# Altium schematic sheet CM001_V4_3.SchDoc(CM001_V4_3)
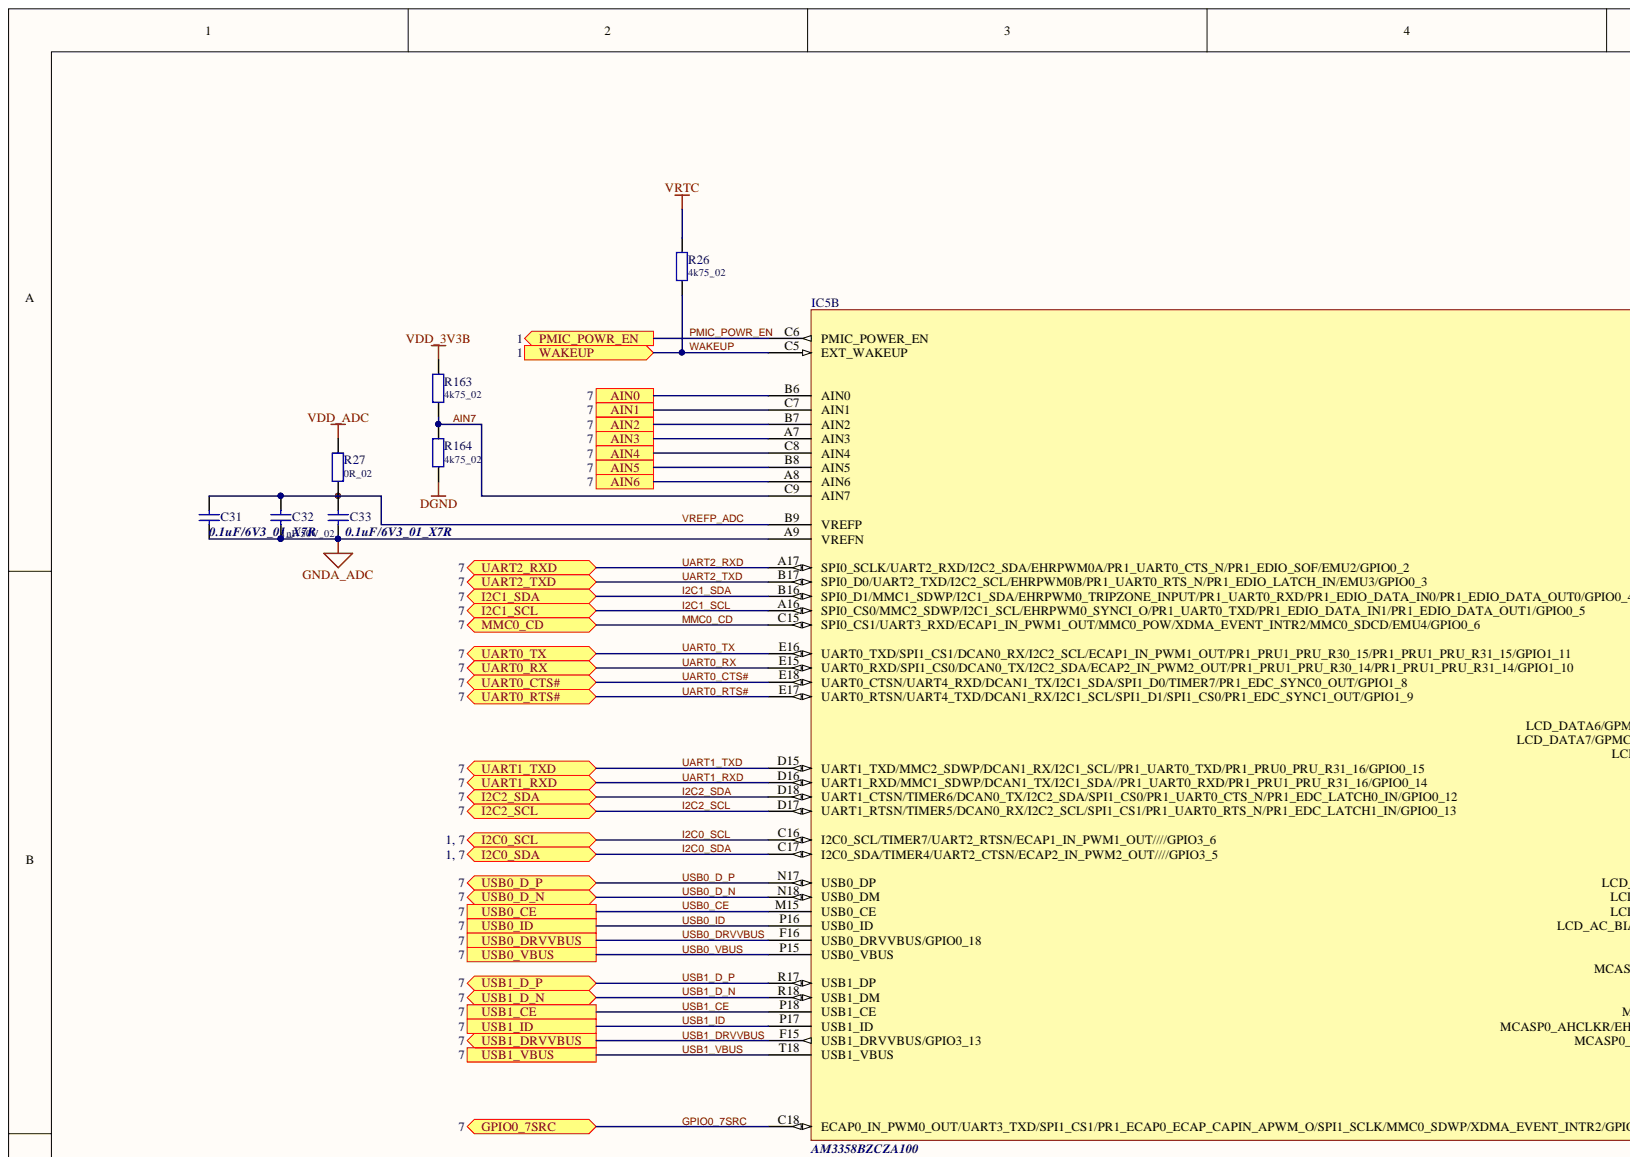
[diagram p0: CM001_V4_3.SchDoc, top left]
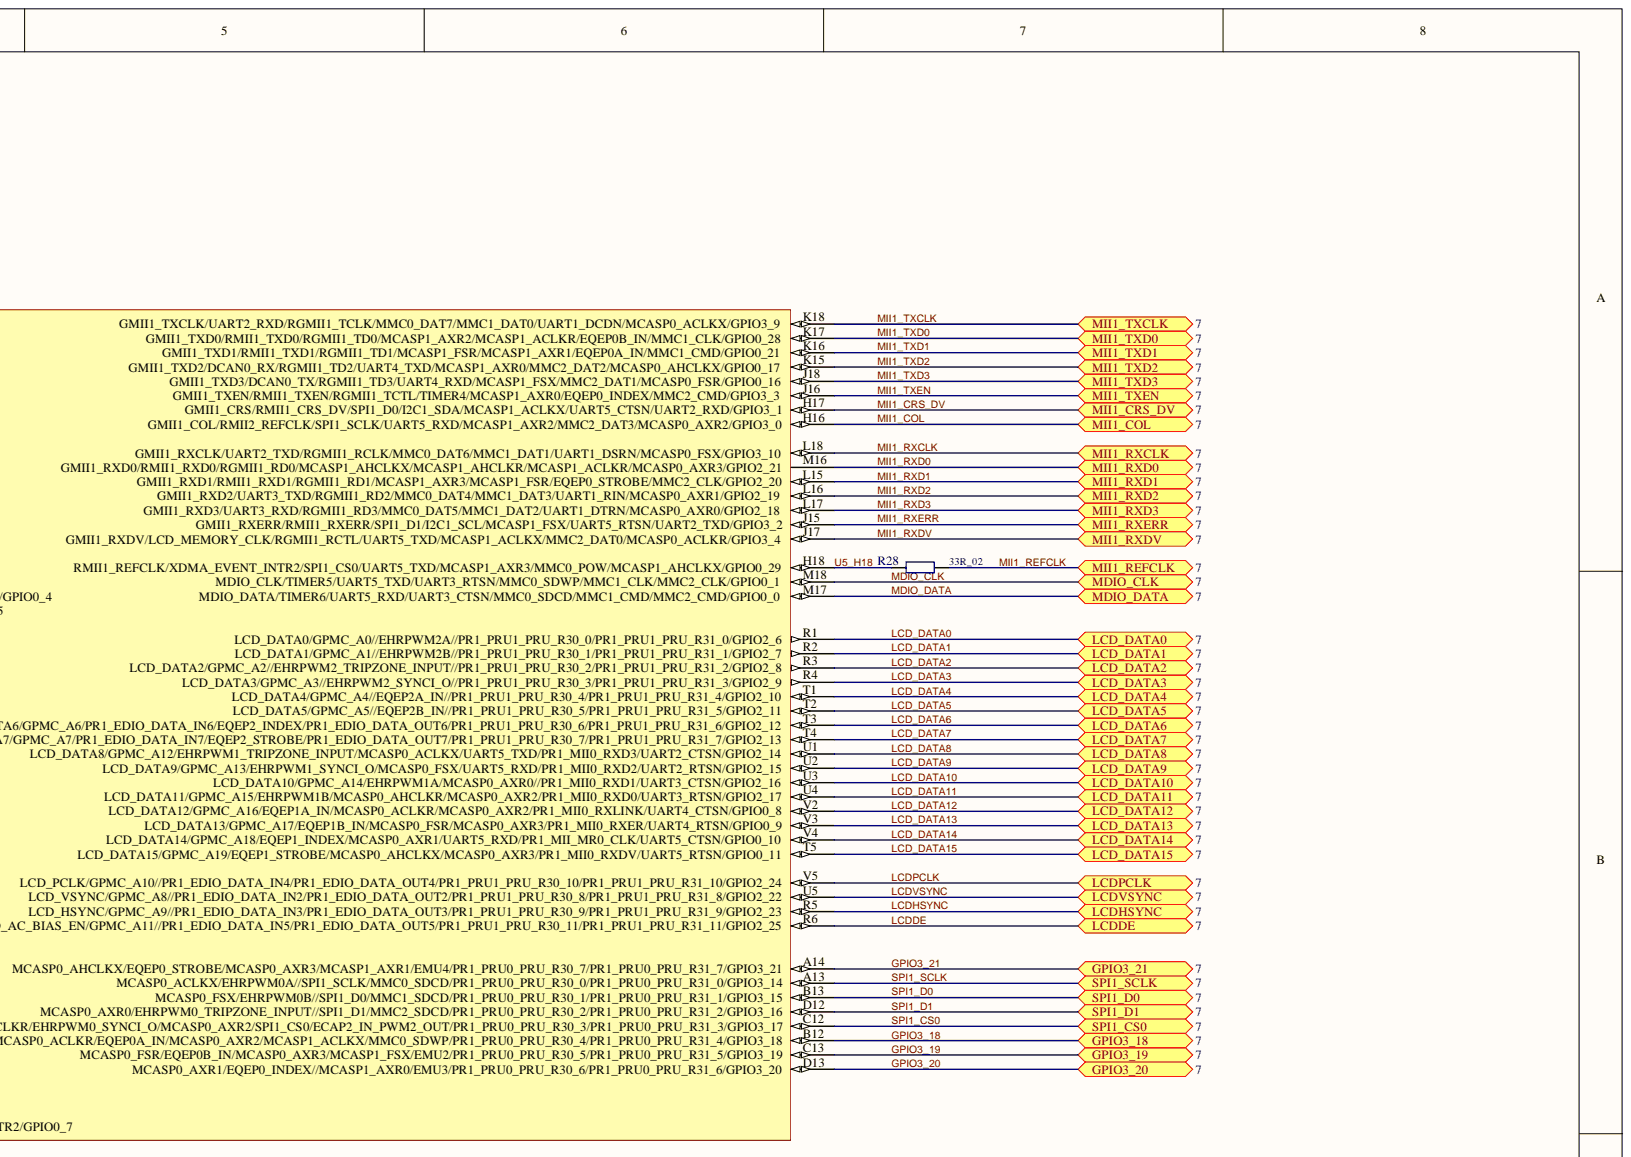
[diagram p1: CM001_V4_3.SchDoc, top right]
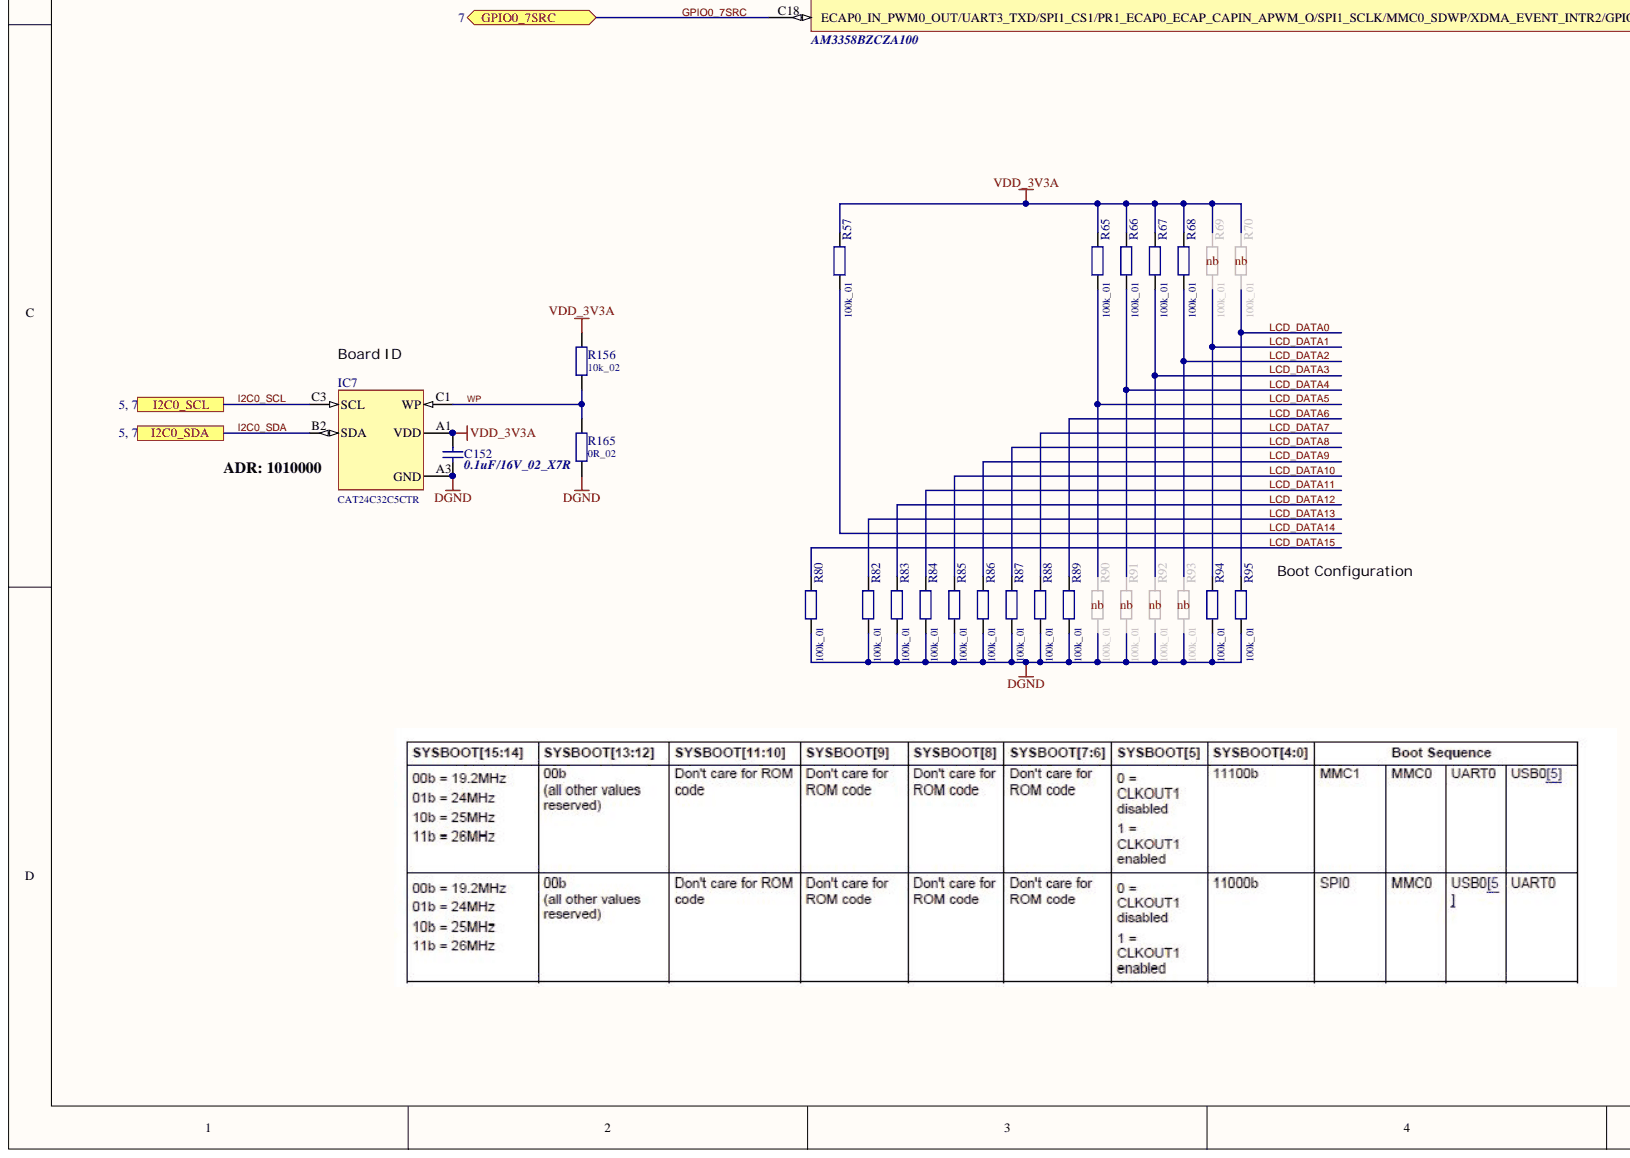
[diagram p2: CM001_V4_3.SchDoc, bottom left]
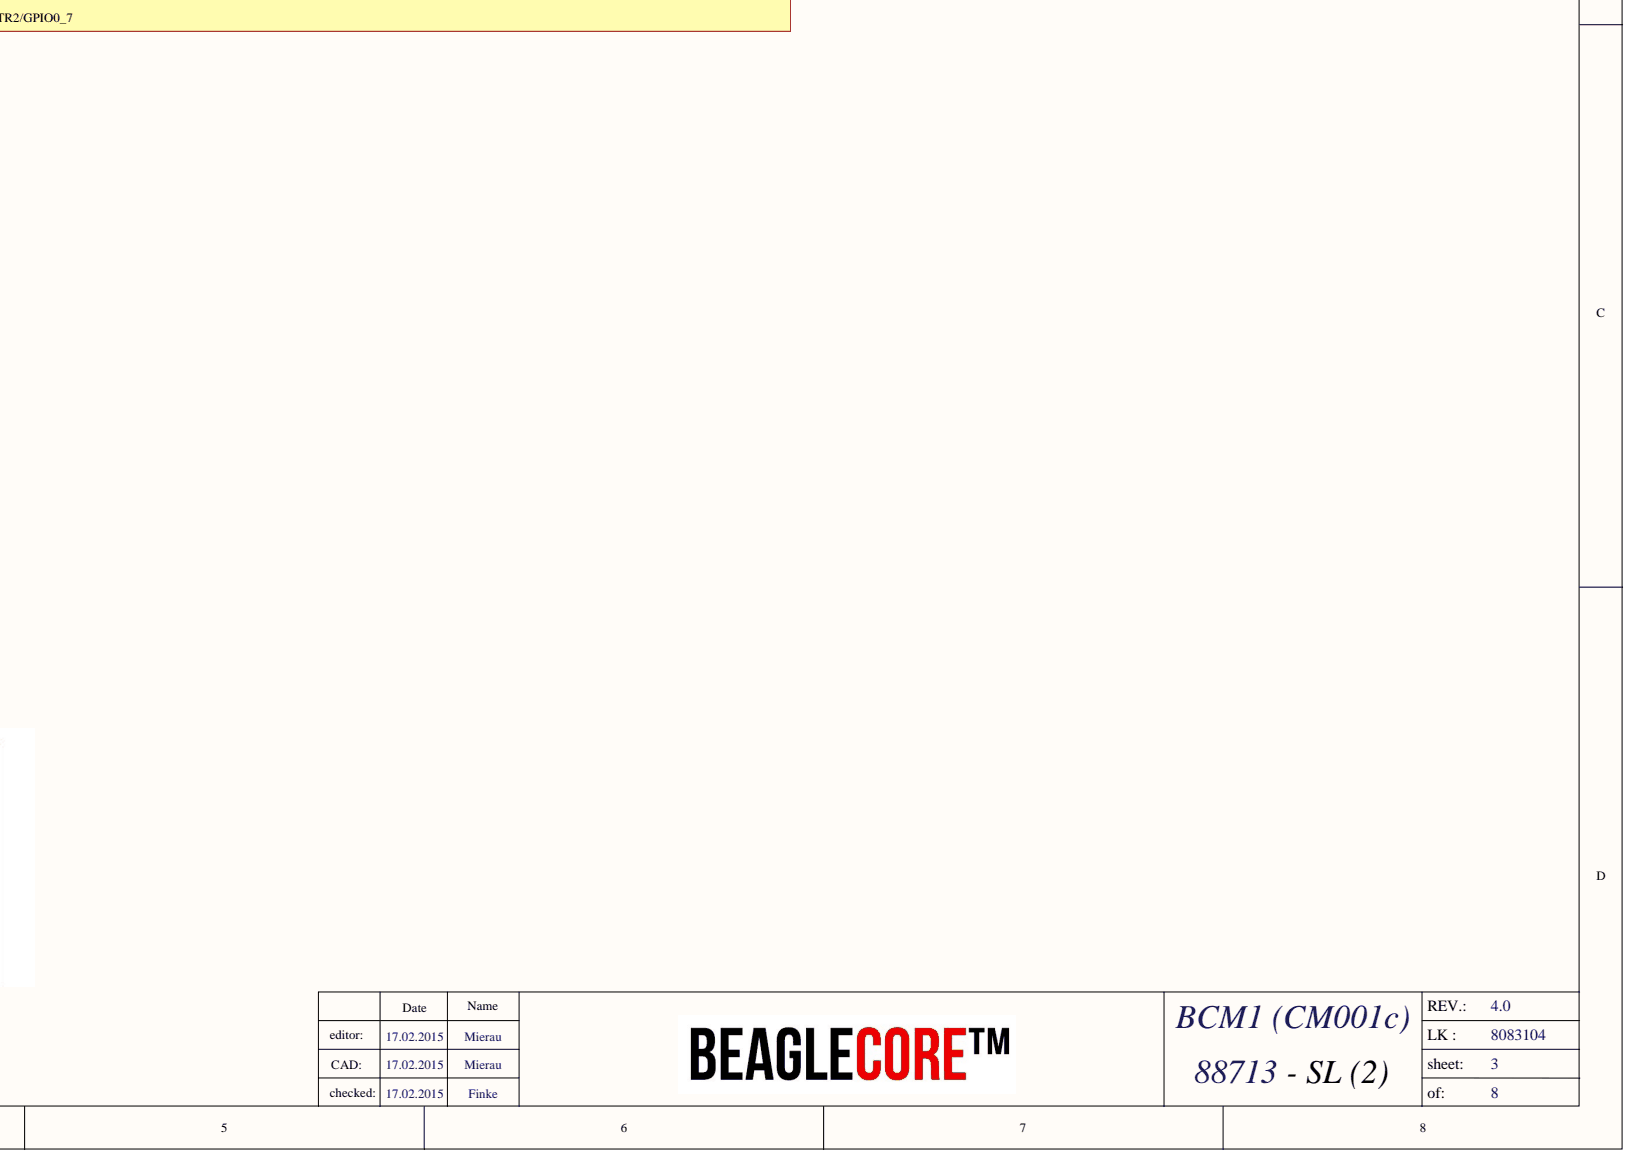
[diagram p3: CM001_V4_3.SchDoc, bottom right]

## Parts
C31  0.1uF/6V3_01_X7R  [CC0603-0201]
C31
C32  1nF/50V_02  [CC1005-0402]
C33  0.1uF/6V3_01_X7R  [CC0603-0201]
C33
C152  0.1uF/16V_02_X7R  [CC1005-0402]
C152  0.1uF/16V_02_X7R  [CC1005-0402]
IC5  AM3358BZCZ100  [BGA324_RM0.8_15x15]  (value not drawn)  (part B)
IC7  CAT24C32C5CTR  [WLCSP5]
R26  4k75_02  [CR1005-0402]
R27  0R_02  [CR1005-0402]
R28  33R_02  [CR1005-0402]
R57  100k_01  [CR0603-0201]
R65  100k_01  [CR0603-0201]
R66  100k_01  [CR0603-0201]
R67  100k_01  [CR0603-0201]
R68  100k_01  [CR0603-0201]
R69  100k_01  [CR0603-0201]
R70  100k_01  [CR0603-0201]
R80  100k_01  [CR0603-0201]
R82  100k_01  [CR0603-0201]
R83  100k_01  [CR0603-0201]
R84  100k_01  [CR0603-0201]
R85  100k_01  [CR0603-0201]
R86  100k_01  [CR0603-0201]
R87  100k_01  [CR0603-0201]
R88  100k_01  [CR0603-0201]
R89  100k_01  [CR0603-0201]
R90  100k_01  [CR0603-0201]
R91  100k_01  [CR0603-0201]
R92  100k_01  [CR0603-0201]
R93  100k_01  [CR0603-0201]
R94  100k_01  [CR0603-0201]
R95  100k_01  [CR0603-0201]
R156  10k_02  [CR1005-0402]
R163  4k75_02  [CR1005-0402]
R164  4k75_02  [CR1005-0402]
R165  0R_02  [CR1005-0402]

## Ports
AIN0, AIN1, AIN2, AIN3, AIN4, AIN5, AIN6, GPIO0_7SRC, GPIO3_18, GPIO3_19, GPIO3_20, GPIO3_21, I2C0_SCL, I2C0_SDA, I2C1_SCL, I2C1_SDA, I2C2_SCL, I2C2_SDA, LCD_DATA0, LCD_DATA1, LCD_DATA2, LCD_DATA3, LCD_DATA4, LCD_DATA5, LCD_DATA6, LCD_DATA7, LCD_DATA8, LCD_DATA9, LCD_DATA10, LCD_DATA11, LCD_DATA12, LCD_DATA13, LCD_DATA14, LCD_DATA15, LCDDE, LCDHSYNC, LCDPCLK, LCDVSYNC, MDIO_CLK, MDIO_DATA, MII1_COL, MII1_CRS_DV, MII1_REFCLK, MII1_RXCLK, MII1_RXD0, MII1_RXD1, MII1_RXD2, MII1_RXD3, MII1_RXDV, MII1_RXERR, MII1_TXCLK, MII1_TXD0, MII1_TXD1, MII1_TXD2, MII1_TXD3, MII1_TXEN, MMC0_CD, PMIC_POWR_EN, SPI1_CS0, SPI1_D0, SPI1_D1, SPI1_SCLK, UART0_CTS#, UART0_RTS#, UART0_RX, UART0_TX, UART1_RXD, UART1_TXD, UART2_RXD, UART2_TXD, USB0_CE, USB0_D_N, USB0_D_P, USB0_DRVVBUS, USB0_ID, USB0_VBUS, USB1_CE, USB1_D_N, USB1_D_P, USB1_DRVVBUS, USB1_ID, USB1_VBUS, WAKEUP

## Nets
DGND: C152.2 IC7.A3(GND) R80.1 R82.1 R83.1 R84.1 R85.1 R86.1 R87.1 R88.1 R89.1 R90.1 R91.1 R92.1 R93.1 R94.1 R95.1 R164.1 R165.1
GNDA_ADC: C31.1 C32.1 C33.1 IC5.A9(VREFN)
VDD_3V3A: C152.1 IC7.A1(VDD) R57.2 R65.2 R66.2 R67.2 R68.2 R69.2 R70.2 R156.2
VDD_3V3B: R163.2
VDD_ADC: R27.2
VRTC: R26.2
AIN0: IC5.B6(AIN0) ; port AIN0
AIN1: IC5.C7(AIN1) ; port AIN1
AIN2: IC5.B7(AIN2) ; port AIN2
AIN3: IC5.A7(AIN3) ; port AIN3
AIN4: IC5.C8(AIN4) ; port AIN4
AIN5: IC5.B8(AIN5) ; port AIN5
AIN6: IC5.A8(AIN6) ; port AIN6
AIN7: IC5.C9(AIN7) R163.1 R164.2
GPIO0_7SRC: IC5.C18(ECAP0_IN_PWM0_OUT/UART3_TXD/SPI1_CS1/PR1_ECAP0_ECAP_CAPIN_APWM_O/SPI1_SCLK/MMC0_SDWP/XDMA_EVENT_INTR2/GPIO0_7) ; port GPIO0_7SRC
GPIO3_18: IC5.B12(MCASP0_ACLKR/EQEP0A_IN/MCASP0_AXR2/MCASP1_ACLKX/MMC0_SDWP/PR1_PRU0_PRU_R30_4/PR1_PRU0_PRU_R31_4/GPIO3_18) ; port GPIO3_18
GPIO3_19: IC5.C13(MCASP0_FSR/EQEP0B_IN/MCASP0_AXR3/MCASP1_FSX/EMU2/PR1_PRU0_PRU_R30_5/PR1_PRU0_PRU_R31_5/GPIO3_19) ; port GPIO3_19
GPIO3_20: IC5.D13(MCASP0_AXR1/EQEP0_INDEX//MCASP1_AXR0/EMU3/PR1_PRU0_PRU_R30_6/PR1_PRU0_PRU_R31_6/GPIO3_20) ; port GPIO3_20
GPIO3_21: IC5.A14(MCASP0_AHCLKX/EQEP0_STROBE/MCASP0_AXR3/MCASP1_AXR1/EMU4/PR1_PRU0_PRU_R30_7/PR1_PRU0_PRU_R31_7/GPIO3_21) ; port GPIO3_21
I2C0_SCL: IC5.C16(I2C0_SCL/TIMER7/UART2_RTSN/ECAP1_IN_PWM1_OUT////GPIO3_6) IC7.C3(SCL) ; port I2C0_SCL
I2C0_SDA: IC5.C17(I2C0_SDA/TIMER4/UART2_CTSN/ECAP2_IN_PWM2_OUT////GPIO3_5) IC7.B2(SDA) ; port I2C0_SDA
I2C1_SCL: IC5.A16(SPI0_CS0/MMC2_SDWP/I2C1_SCL/EHRPWM0_SYNCI_O/PR1_UART0_TXD/PR1_EDIO_DATA_IN1/PR1_EDIO_DATA_OUT1/GPIO0_5) ; port I2C1_SCL
I2C1_SDA: IC5.B16(SPI0_D1/MMC1_SDWP/I2C1_SDA/EHRPWM0_TRIPZONE_INPUT/PR1_UART0_RXD/PR1_EDIO_DATA_IN0/PR1_EDIO_DATA_OUT0/GPIO0_4) ; port I2C1_SDA
I2C2_SCL: IC5.D17(UART1_RTSN/TIMER5/DCAN0_RX/I2C2_SCL/SPI1_CS1/PR1_UART0_RTS_N/PR1_EDC_LATCH1_IN/GPIO0_13) ; port I2C2_SCL
I2C2_SDA: IC5.D18(UART1_CTSN/TIMER6/DCAN0_TX/I2C2_SDA/SPI1_CS0/PR1_UART0_CTS_N/PR1_EDC_LATCH0_IN/GPIO0_12) ; port I2C2_SDA
LCD_DATA0: IC5.R1(LCD_DATA0/GPMC_A0//EHRPWM2A//PR1_PRU1_PRU_R30_0/PR1_PRU1_PRU_R31_0/GPIO2_6) R70.1 R95.2 ; port LCD_DATA0
LCD_DATA1: IC5.R2(LCD_DATA1/GPMC_A1//EHRPWM2B//PR1_PRU1_PRU_R30_1/PR1_PRU1_PRU_R31_1/GPIO2_7) R69.1 R94.2 ; port LCD_DATA1
LCD_DATA2: IC5.R3(LCD_DATA2/GPMC_A2//EHRPWM2_TRIPZONE_INPUT//PR1_PRU1_PRU_R30_2/PR1_PRU1_PRU_R31_2/GPIO2_8) R68.1 R93.2 ; port LCD_DATA2
LCD_DATA3: IC5.R4(LCD_DATA3/GPMC_A3//EHRPWM2_SYNCI_O//PR1_PRU1_PRU_R30_3/PR1_PRU1_PRU_R31_3/GPIO2_9) R67.1 R92.2 ; port LCD_DATA3
LCD_DATA4: IC5.T1(LCD_DATA4/GPMC_A4//EQEP2A_IN//PR1_PRU1_PRU_R30_4/PR1_PRU1_PRU_R31_4/GPIO2_10) R66.1 R91.2 ; port LCD_DATA4
LCD_DATA5: IC5.T2(LCD_DATA5/GPMC_A5//EQEP2B_IN//PR1_PRU1_PRU_R30_5/PR1_PRU1_PRU_R31_5/GPIO2_11) R65.1 R90.2 ; port LCD_DATA5
LCD_DATA6: IC5.T3(LCD_DATA6/GPMC_A6/PR1_EDIO_DATA_IN6/EQEP2_INDEX/PR1_EDIO_DATA_OUT6/PR1_PRU1_PRU_R30_6/PR1_PRU1_PRU_R31_6/GPIO2_12) R89.2 ; port LCD_DATA6
LCD_DATA7: IC5.T4(LCD_DATA7/GPMC_A7/PR1_EDIO_DATA_IN7/EQEP2_STROBE/PR1_EDIO_DATA_OUT7/PR1_PRU1_PRU_R30_7/PR1_PRU1_PRU_R31_7/GPIO2_13) R88.2 ; port LCD_DATA7
LCD_DATA8: IC5.U1(LCD_DATA8/GPMC_A12/EHRPWM1_TRIPZONE_INPUT/MCASP0_ACLKX/UART5_TXD/PR1_MII0_RXD3/UART2_CTSN/GPIO2_14) R87.2 ; port LCD_DATA8
LCD_DATA9: IC5.U2(LCD_DATA9/GPMC_A13/EHRPWM1_SYNCI_O/MCASP0_FSX/UART5_RXD/PR1_MII0_RXD2/UART2_RTSN/GPIO2_15) R86.2 ; port LCD_DATA9
LCD_DATA10: IC5.U3(LCD_DATA10/GPMC_A14/EHRPWM1A/MCASP0_AXR0//PR1_MII0_RXD1/UART3_CTSN/GPIO2_16) R85.2 ; port LCD_DATA10
LCD_DATA11: IC5.U4(LCD_DATA11/GPMC_A15/EHRPWM1B/MCASP0_AHCLKR/MCASP0_AXR2/PR1_MII0_RXD0/UART3_RTSN/GPIO2_17) R84.2 ; port LCD_DATA11
LCD_DATA12: IC5.V2(LCD_DATA12/GPMC_A16/EQEP1A_IN/MCASP0_ACLKR/MCASP0_AXR2/PR1_MII0_RXLINK/UART4_CTSN/GPIO0_8) R83.2 ; port LCD_DATA12
LCD_DATA13: IC5.V3(LCD_DATA13/GPMC_A17/EQEP1B_IN/MCASP0_FSR/MCASP0_AXR3/PR1_MII0_RXER/UART4_RTSN/GPIO0_9) R82.2 ; port LCD_DATA13
LCD_DATA14: IC5.V4(LCD_DATA14/GPMC_A18/EQEP1_INDEX/MCASP0_AXR1/UART5_RXD/PR1_MII_MR0_CLK/UART5_CTSN/GPIO0_10) R57.1 ; port LCD_DATA14
LCD_DATA15: IC5.T5(LCD_DATA15/GPMC_A19/EQEP1_STROBE/MCASP0_AHCLKX/MCASP0_AXR3/PR1_MII0_RXDV/UART5_RTSN/GPIO0_11) R80.2 ; port LCD_DATA15
LCDDE: IC5.R6(LCD_AC_BIAS_EN/GPMC_A11//PR1_EDIO_DATA_IN5/PR1_EDIO_DATA_OUT5/PR1_PRU1_PRU_R30_11/PR1_PRU1_PRU_R31_11/GPIO2_25) ; port LCDDE
LCDHSYNC: IC5.R5(LCD_HSYNC/GPMC_A9//PR1_EDIO_DATA_IN3/PR1_EDIO_DATA_OUT3/PR1_PRU1_PRU_R30_9/PR1_PRU1_PRU_R31_9/GPIO2_23) ; port LCDHSYNC
LCDPCLK: IC5.V5(LCD_PCLK/GPMC_A10//PR1_EDIO_DATA_IN4/PR1_EDIO_DATA_OUT4/PR1_PRU1_PRU_R30_10/PR1_PRU1_PRU_R31_10/GPIO2_24) ; port LCDPCLK
LCDVSYNC: IC5.U5(LCD_VSYNC/GPMC_A8//PR1_EDIO_DATA_IN2/PR1_EDIO_DATA_OUT2/PR1_PRU1_PRU_R30_8/PR1_PRU1_PRU_R31_8/GPIO2_22) ; port LCDVSYNC
MDIO_CLK: IC5.M18(MDIO_CLK/TIMER5/UART5_TXD/UART3_RTSN/MMC0_SDWP/MMC1_CLK/MMC2_CLK/GPIO0_1) ; port MDIO_CLK
MDIO_DATA: IC5.M17(MDIO_DATA/TIMER6/UART5_RXD/UART3_CTSN/MMC0_SDCD/MMC1_CMD/MMC2_CMD/GPIO0_0) ; port MDIO_DATA
MII1_COL: IC5.H16(GMII1_COL/RMII2_REFCLK/SPI1_SCLK/UART5_RXD/MCASP1_AXR2/MMC2_DAT3/MCASP0_AXR2/GPIO3_0) ; port MII1_COL
MII1_CRS_DV: IC5.H17(GMII1_CRS/RMII1_CRS_DV/SPI1_D0/I2C1_SDA/MCASP1_ACLKX/UART5_CTSN/UART2_RXD/GPIO3_1) ; port MII1_CRS_DV
MII1_REFCLK: R28.2 ; port MII1_REFCLK
MII1_RXCLK: IC5.L18(GMII1_RXCLK/UART2_TXD/RGMII1_RCLK/MMC0_DAT6/MMC1_DAT1/UART1_DSRN/MCASP0_FSX/GPIO3_10) ; port MII1_RXCLK
MII1_RXD0: IC5.M16(GMII1_RXD0/RMII1_RXD0/RGMII1_RD0/MCASP1_AHCLKX/MCASP1_AHCLKR/MCASP1_ACLKR/MCASP0_AXR3/GPIO2_21) ; port MII1_RXD0
MII1_RXD1: IC5.L15(GMII1_RXD1/RMII1_RXD1/RGMII1_RD1/MCASP1_AXR3/MCASP1_FSR/EQEP0_STROBE/MMC2_CLK/GPIO2_20) ; port MII1_RXD1
MII1_RXD2: IC5.L16(GMII1_RXD2/UART3_TXD/RGMII1_RD2/MMC0_DAT4/MMC1_DAT3/UART1_RIN/MCASP0_AXR1/GPIO2_19) ; port MII1_RXD2
MII1_RXD3: IC5.L17(GMII1_RXD3/UART3_RXD/RGMII1_RD3/MMC0_DAT5/MMC1_DAT2/UART1_DTRN/MCASP0_AXR0/GPIO2_18) ; port MII1_RXD3
MII1_RXDV: IC5.J17(GMII1_RXDV/LCD_MEMORY_CLK/RGMII1_RCTL/UART5_TXD/MCASP1_ACLKX/MMC2_DAT0/MCASP0_ACLKR/GPIO3_4) ; port MII1_RXDV
MII1_RXERR: IC5.J15(GMII1_RXERR/RMII1_RXERR/SPI1_D1/I2C1_SCL/MCASP1_FSX/UART5_RTSN/UART2_TXD/GPIO3_2) ; port MII1_RXERR
MII1_TXCLK: IC5.K18(GMII1_TXCLK/UART2_RXD/RGMII1_TCLK/MMC0_DAT7/MMC1_DAT0/UART1_DCDN/MCASP0_ACLKX/GPIO3_9) ; port MII1_TXCLK
MII1_TXD0: IC5.K17(GMII1_TXD0/RMII1_TXD0/RGMII1_TD0/MCASP1_AXR2/MCASP1_ACLKR/EQEP0B_IN/MMC1_CLK/GPIO0_28) ; port MII1_TXD0
MII1_TXD1: IC5.K16(GMII1_TXD1/RMII1_TXD1/RGMII1_TD1/MCASP1_FSR/MCASP1_AXR1/EQEP0A_IN/MMC1_CMD/GPIO0_21) ; port MII1_TXD1
MII1_TXD2: IC5.K15(GMII1_TXD2/DCAN0_RX/RGMII1_TD2/UART4_TXD/MCASP1_AXR0/MMC2_DAT2/MCASP0_AHCLKX/GPIO0_17) ; port MII1_TXD2
MII1_TXD3: IC5.J18(GMII1_TXD3/DCAN0_TX/RGMII1_TD3/UART4_RXD/MCASP1_FSX/MMC2_DAT1/MCASP0_FSR/GPIO0_16) ; port MII1_TXD3
MII1_TXEN: IC5.J16(GMII1_TXEN/RMII1_TXEN/RGMII1_TCTL/TIMER4/MCASP1_AXR0/EQEP0_INDEX/MMC2_CMD/GPIO3_3) ; port MII1_TXEN
MMC0_CD: IC5.C15(SPI0_CS1/UART3_RXD/ECAP1_IN_PWM1_OUT/MMC0_POW/XDMA_EVENT_INTR2/MMC0_SDCD/EMU4/GPIO0_6) ; port MMC0_CD
PMIC_POWR_EN: IC5.C6(PMIC_POWER_EN) ; port PMIC_POWR_EN
SPI1_CS0: IC5.C12(MCASP0_AHCLKR/EHRPWM0_SYNCI_O/MCASP0_AXR2/SPI1_CS0/ECAP2_IN_PWM2_OUT/PR1_PRU0_PRU_R30_3/PR1_PRU0_PRU_R31_3/GPIO3_17) ; port SPI1_CS0
SPI1_D0: IC5.B13(MCASP0_FSX/EHRPWM0B//SPI1_D0/MMC1_SDCD/PR1_PRU0_PRU_R30_1/PR1_PRU0_PRU_R31_1/GPIO3_15) ; port SPI1_D0
SPI1_D1: IC5.D12(MCASP0_AXR0/EHRPWM0_TRIPZONE_INPUT//SPI1_D1/MMC2_SDCD/PR1_PRU0_PRU_R30_2/PR1_PRU0_PRU_R31_2/GPIO3_16) ; port SPI1_D1
SPI1_SCLK: IC5.A13(MCASP0_ACLKX/EHRPWM0A//SPI1_SCLK/MMC0_SDCD/PR1_PRU0_PRU_R30_0/PR1_PRU0_PRU_R31_0/GPIO3_14) ; port SPI1_SCLK
U5_H18: IC5.H18(RMII1_REFCLK/XDMA_EVENT_INTR2/SPI1_CS0/UART5_TXD/MCASP1_AXR3/MMC0_POW/MCASP1_AHCLKX/GPIO0_29) R28.1
UART0_CTS#: IC5.E18(UART0_CTSN/UART4_RXD/DCAN1_TX/I2C1_SDA/SPI1_D0/TIMER7/PR1_EDC_SYNC0_OUT/GPIO1_8) ; port UART0_CTS#
UART0_RTS#: IC5.E17(UART0_RTSN/UART4_TXD/DCAN1_RX/I2C1_SCL/SPI1_D1/SPI1_CS0/PR1_EDC_SYNC1_OUT/GPIO1_9) ; port UART0_RTS#
UART0_RX: IC5.E15(UART0_RXD/SPI1_CS0/DCAN0_TX/I2C2_SDA/ECAP2_IN_PWM2_OUT/PR1_PRU1_PRU_R30_14/PR1_PRU1_PRU_R31_14/GPIO1_10) ; port UART0_RX
UART0_TX: IC5.E16(UART0_TXD/SPI1_CS1/DCAN0_RX/I2C2_SCL/ECAP1_IN_PWM1_OUT/PR1_PRU1_PRU_R30_15/PR1_PRU1_PRU_R31_15/GPIO1_11) ; port UART0_TX
UART1_RXD: IC5.D16(UART1_RXD/MMC1_SDWP/DCAN1_TX/I2C1_SDA//PR1_UART0_RXD/PR1_PRU1_PRU_R31_16/GPIO0_14) ; port UART1_RXD
UART1_TXD: IC5.D15(UART1_TXD/MMC2_SDWP/DCAN1_RX/I2C1_SCL//PR1_UART0_TXD/PR1_PRU0_PRU_R31_16/GPIO0_15) ; port UART1_TXD
UART2_RXD: IC5.A17(SPI0_SCLK/UART2_RXD/I2C2_SDA/EHRPWM0A/PR1_UART0_CTS_N/PR1_EDIO_SOF/EMU2/GPIO0_2) ; port UART2_RXD
UART2_TXD: IC5.B17(SPI0_D0/UART2_TXD/I2C2_SCL/EHRPWM0B/PR1_UART0_RTS_N/PR1_EDIO_LATCH_IN/EMU3/GPIO0_3) ; port UART2_TXD
USB0_CE: IC5.M15(USB0_CE) ; port USB0_CE
USB0_D_N: IC5.N18(USB0_DM) ; port USB0_D_N
USB0_D_P: IC5.N17(USB0_DP) ; port USB0_D_P
USB0_DRVVBUS: IC5.F16(USB0_DRVVBUS/GPIO0_18) ; port USB0_DRVVBUS
USB0_ID: IC5.P16(USB0_ID) ; port USB0_ID
USB0_VBUS: IC5.P15(USB0_VBUS) ; port USB0_VBUS
USB1_CE: IC5.P18(USB1_CE) ; port USB1_CE
USB1_D_N: IC5.R18(USB1_DM) ; port USB1_D_N
USB1_D_P: IC5.R17(USB1_DP) ; port USB1_D_P
USB1_DRVVBUS: IC5.F15(USB1_DRVVBUS/GPIO3_13) ; port USB1_DRVVBUS
USB1_ID: IC5.P17(USB1_ID) ; port USB1_ID
USB1_VBUS: IC5.T18(USB1_VBUS) ; port USB1_VBUS
VREFP_ADC: C31.2 C32.2 C33.2 IC5.B9(VREFP) R27.1
WAKEUP: IC5.C5(EXT_WAKEUP) R26.1 ; port WAKEUP
WP: IC7.C1(WP) R156.1 R165.2
N00246:
N00247:
N00248:
N00249:

## Library data of the parts
C31 C33 = C0.1uF/6V3_01_X7R: Capacitor 0201 6,3V X7R; S/T: SMD; Hersteller: any; erstellt von: Finke; Art-Nr.: 08.0002.0___; Produktbeispiel: Gudeco: muRata: GRM033R70J103JA01D; Value: 0.1uF/6V3_01_X7R; Supplier Part Number 2: 8USl_ZajxFVxkJsfRx-2TMMxBpVDD3GHQuOpPGq7oYPTEIlO4Ffw3x4_A6bjf4Mm; Supplier Part Number 1: 490-3167-6-ND; Supplier 2: Arrow; Supplier 1: Digi-Key; Component Kind: Standard; Bestellbezeichnung: *; Library Name: C_ies_Integrated_Library.IntLib
C32 = C1nF/50V_02: Capacitor 0402 X7R 50V; S/T: SMD; Erstellt von: Krüger; Art-Nr.: 08.0002.0009; Value: 1nF/50V_02; Component Kind: Standard; Library Name: C_ies_Integrated_Library.IntLib
C152 = C0.1uF/16V_02_X7R: Capacitor 0402 16V X7R; S/T: SMD; Hersteller1: muRata; Bestellbezeichnung1: GCM188R70J225KE22D; Art-Nr.: 08.0002.0___; Value: 0.1uF/16V_02_X7R; Lieferant: *; Hersteller: *; Component Kind: Standard; Bestellbezeichnung: *; Library Name: C_ies_Integrated_Library.IntLib
C152 = C0.1uF/16V_02_X7R: Capacitor 0402 16V X7R; S/T: SMD; erstellt von: FAD; Art-Nr.: 08.0002.0___; Bestellbezeichnung1: GCM188R70J225KE22D; Hersteller1: muRata; Value: 0.1uF/16V_02_X7R; Lieferant: *; Hersteller: *; Component Kind: Standard; Bestellbezeichnung: *; Library Name: C_ies_Integrated_Library.IntLib
IC5 = ICAM3358BZCZ100: Cortex A8 Processor 15x15; Hersteller: Texas Instruments; Art-Nr.: 04.0701.; Value: AM3358BZCZ100; ComponentLink1URL: http://www.ti.com/lit/ds/symlink/am3354.pdf; ComponentLink1Description: Link TI; Component Kind: Standard; Bestellbezeichnung: AM3358BZCZ100; Library Name: IC_ies_Integrated_Library.IntLib
IC7 = ICCAT24C32C5CTR: Serial EEPROM; 2-wire, 8192 x 8 bits; S/T: SMD; Hersteller: ON SEMI; Art-Nr.: 04.0701.; Value: CAT24C32C5CTR; ComponentLink1Description: Datenblatt; Component Kind: Standard; Bestellbezeichnung: CAT24C32C5CTR; Library Name: IC_ies_Integrated_Library.IntLib; ComponentLink1URL: U:\IC_Integrierte Bauteile\ON Semi\CAT24C32-D.PDF
R26 R163 R164 = R4k75_02: Resistor; 0402; 1%; S/T: SMD; Art-Nr.: 09.0002.0017; Value: 4k75_02; Component Kind: Standard; Library Name: R_ies_Integrated_Library.IntLib
R27 R165 = R0R_02: Resistor; 0402; 5%; S/T: SMD; Art-Nr.: 09.0002.0002; Value: 0R_02; Supplier Part Number 2: Digi-Key - 311-0.0JRCT-ND; Supplier Part Number 1: 311-0.0JRCT-ND; Supplier 2: Octopart; Supplier 1: Digi-Key; Component Kind: Standard; Library Name: R_ies_Integrated_Library.IntLib
R28 = R33R_02: Resistor; 0402; 1%; S/T: SMD; Art-Nr.: 09.0002.0014; Value: 33R_02; Component Kind: Standard; Library Name: R_ies_Integrated_Library.IntLib
R57 R65-R70 R80 R82-R95 = R100k_01: Resistor 0201, 1/20W; S/T: SMD; Art-Nr.: 09.0002.0070; Value: 100k_01; Component Kind: Standard; Library Name: R_ies_Integrated_Library.IntLib
R156 = R10k_02: Resistor; 0402; 1%; S/T: SMD; Art-Nr.: 09.0002.0004; Value: 10k_02; Supplier Part Number 2: Digi-Key - 311-10.0KLRCT-ND; Supplier Part Number 1: 311-10.0KLRCT-ND; Supplier 2: Octopart; Supplier 1: Digi-Key; Component Kind: Standard; Library Name: R_ies_Integrated_Library.IntLib
